# Altium schematic sheet HAL_3.SchDoc
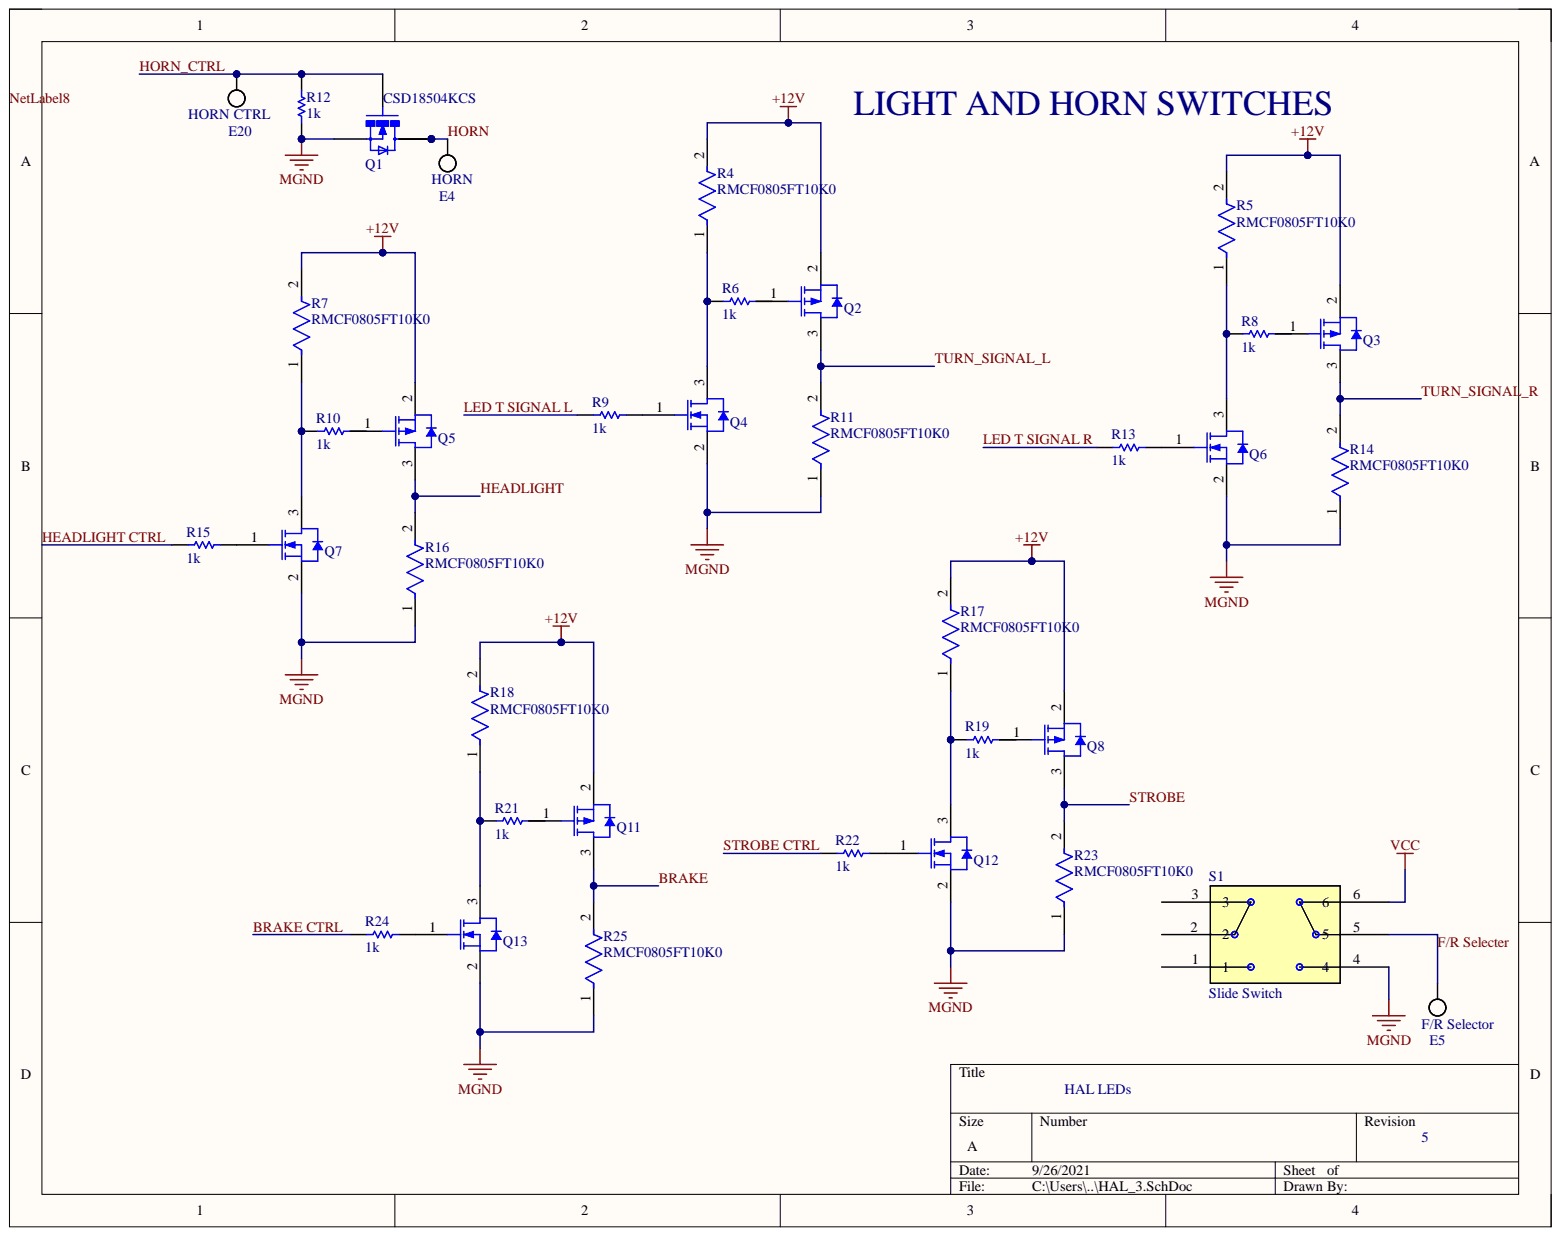
[diagram p0: HAL_3.SchDoc]

## Parts
E4  HORN  [EPAD]  (no BOM)
E5  F/R Selector  [EPAD]  (no BOM)
E20  HORN CTRL  [EPAD]  (no BOM)
Q1  CSD18504KCS  [TO254P1651X1067X470-3]
Q2  IRLML6402TRPBF  [INTR-SOT-23-3_V]  (value not drawn)
Q3  IRLML6402TRPBF  [INTR-SOT-23-3_V]  (value not drawn)
Q4  NTR4501NT1G  [ONSC-SOT-23-3-318-08_V]  (value not drawn)
Q5  IRLML6402TRPBF  [INTR-SOT-23-3_V]  (value not drawn)
Q6  NTR4501NT1G  [ONSC-SOT-23-3-318-08_V]  (value not drawn)
Q7  NTR4501NT1G  [ONSC-SOT-23-3-318-08_V]  (value not drawn)
Q8  IRLML6402TRPBF  [INTR-SOT-23-3_V]  (value not drawn)
Q11  IRLML6402TRPBF  [INTR-SOT-23-3_V]  (value not drawn)
Q12  NTR4501NT1G  [ONSC-SOT-23-3-318-08_V]  (value not drawn)
Q13  NTR4501NT1G  [ONSC-SOT-23-3-318-08_V]  (value not drawn)
R4  RMCF0805FT10K0  [FP-RMCF0805-MFG]
R5  RMCF0805FT10K0  [FP-RMCF0805-MFG]
R6  1k  [RESC3216X70X40LL20T20]
R7  RMCF0805FT10K0  [FP-RMCF0805-MFG]
R8  1k  [RESC3216X70X40LL20T20]
R9  1k  [RESC3216X70X40LL20T20]
R10  1k  [RESC3216X70X40LL20T20]
R11  RMCF0805FT10K0  [FP-RMCF0805-MFG]
R12  1k  [RESC3216X70X40LL20T20]
R13  1k  [RESC3216X70X40LL20T20]
R14  RMCF0805FT10K0  [FP-RMCF0805-MFG]
R15  1k  [RESC3216X70X40LL20T20]
R16  RMCF0805FT10K0  [FP-RMCF0805-MFG]
R17  RMCF0805FT10K0  [FP-RMCF0805-MFG]
R18  RMCF0805FT10K0  [FP-RMCF0805-MFG]
R19  1k  [RESC3216X70X40LL20T20]
R21  1k  [RESC3216X70X40LL20T20]
R22  1k  [RESC3216X70X40LL20T20]
R23  RMCF0805FT10K0  [FP-RMCF0805-MFG]
R24  1k  [RESC3216X70X40LL20T20]
R25  RMCF0805FT10K0  [FP-RMCF0805-MFG]
S1  Slide Switch  [Slide Switch]

## Nets
+12V: Q2.2 Q3.2 Q5.2 Q8.2 Q11.2 R4.2 R5.2 R7.2 R17.2 R18.2
MGND: Q1.3 Q4.2 Q6.2 Q7.2 Q12.2 Q13.2 R11.1 R12.2 R14.1 R16.1 R23.1 R25.1 S1.4
VCC: S1.6
BRAKE: Q11.3 R25.2
BRAKE CTRL: R24.1
F/R Selecter: E5.1 S1.5
HEADLIGHT: Q5.3 R16.2
HEADLIGHT CTRL: R15.1
HORN: E4.1 Q1.2 Q1.4 Q1.4.1
HORN_CTRL: E20.1 Q1.1 R12.1
LED T SIGNAL L: R9.1
LED T SIGNAL R: R13.1
STROBE: Q8.3 R23.2
STROBE CTRL: R22.1
TURN_SIGNAL_L: Q2.3 R11.2
TURN_SIGNAL_R: Q3.3 R14.2
NetQ4_1: Q4.1 R9.2
NetQ4_3: Q4.3 R4.1 R6.1
NetQ6_1: Q6.1 R13.2
NetQ6_3: Q6.3 R5.1 R8.1
NetQ7_1: Q7.1 R15.2
NetQ7_3: Q7.3 R7.1 R10.1
NetQ12_1: Q12.1 R22.2
NetQ12_3: Q12.3 R17.1 R19.1
NetQ13_1: Q13.1 R24.2
NetQ13_3: Q13.3 R18.1 R21.1
NetLabel8:

Not connected: Q2 1; Q3 1; Q5 1; Q8 1; Q11 1; R6 2; R8 2; R10 2; R19 2; R21 2; S1 1 2 3

## Library data of the parts
E4 E5 E20 = EPAD: Pad for probing signals; Library Name: PrISUm-Discrete.SchLib
Q1 = CSD18502KCS: 40V, N ch NexFET MOSFET, single TO-220, 2.9mOhm 3-TO-220 -55 to 175; MF: Texas Instruments; Availability: Good; Check_prices: https://www.snapeda.com/parts/CSD18502KCS/Texas%20Instruments/view-part/277573/?ref=eda; Component Kind: Standard; Library Name: CSD18502KCS.IntLib; MP: CSD18502KCS; Package: TO-220-3 Texas Instruments; Price: None; Purchase-URL: https://pricing.snapeda.com/search/part/CSD18502KCS/?ref=eda; SnapEDA_Link: https://www.snapeda.com/parts/CSD18502KCS/Texas%20Instruments/view-part/277573/?ref=snap
Q2 Q3 Q5 Q8 Q11 = CMP-2000-04987-1: HEXFET(R) Power P-Channel MOSFET, -20 V, -3.7 A, -55 to 150 degC, 3-Pin SOT-23, RoHS, Tape and Reel; Manufacturer: International Rectifier; Drain-Source On Resistance-Max: 0.065O; Drain-to-Source Voltage [Vdss]: 20V; Qg Gate Charge: 8nC; Rated Power Dissipation: 1.3W; Channel Type: P-Channel; Component Kind: Standard; Datasheet URL: http://datasheet.octopart.com/IRLML6402TRPBF-International-Rectifier-datasheet-93882.pdf; Datasheet Version: Feb-05; Library Name: Altium Content Vault; Manufacturer URL: http://internationalrectifier.com/; Package Description: 3-Pin Small Outline Transistor, Body 2.92 x 1.3 mm, Pitch 0.95 mm; Package Reference: SOT-23-3
Q4 Q6 Q7 Q12 Q13 = CMP-1058-00732-1: Power MOSFET, 20 V, 3.2 A, Single N-Channel, 3-Pin SOT-23, Pb-Free, Tape and Reel; Manufacturer: On Semiconductor; Channel Polarity: N Channel; Ciss Typ (pF): 200; Coss Typ (pF): 80; Crss Typ (pF): 50; PD Max (W): 1.25; Qrr Typ (nC): 3; V(BR)DSS Min (V): 20; VGS Max (V): 12; VGS(th) Max (V): 1.2; Component Kind: Standard; ComponentLink1Description: Manufacturer URL; ComponentLink1URL: http://www.onsemi.com/; ComponentLink2Description: Datasheet; ComponentLink2URL: http://www.onsemi.cn/pub_link/Collateral/NTR4501N-D.PDF; ComponentLink3Description: Package Specification; ComponentLink3URL: http://www.onsemi.cn/pub_link/Collateral/318-08.PDF; Configuration: Single; DatasheetVersion: Rev. 13; Library Name: Altium Content Vault; Mounting Technology: Surface Mount; Package Type: SOT-23-3; PackageDescription: 3-Pin Small Outline Transistor, Body 2.92 x 1.3 mm, Pitch 0.95 mm; PackageReference: SOT-23-3-318-08; PackageVersion: Rev. AP, 11/2009; Packing: Tape and Reel; PartNumber: NTR4501NT1G; RoHS: Pb-Free
R4 R5 R7 R11 R14 R16-R18 R23 R25 = CMP-26527-000121-1: RES 10K OHM 1% 1/8W 0805; Max Power Dissipation: 125mW; Resistance: 10kR; Temperature Coefficient: 100ppm/°C; Case Code (Imperial): 0805; Case Code (Metric): 2012; Case/Package: 0805; Component Kind: Standard; Construction: Rectangular; Contact Plating: Tin; Depth: 1.25mm; Features: Automotive AEC-Q200; Height: 0.026inch; Lead Free: Lead Free; Length: 2mm; Library Name: Altium Content Vault; Max Operating Temperature: 155°C; Military Standard: Not; Min Operating Temperature: -55°C; Mount: Surface Mount; Number of Terminations: 2; Packaging: Tape and Reel; Power Rating: 125mW; Radiation Hardening: No; Resistor Type: Thick Film; RoHS Compliant: Yes; Series: RMCF; Size Code: 0805; Tolerance: 1%; Width: 0.049inch
R6 R8-R10 R12 R13 R15 R19 R21 R22 R24 = CMP-2003-04517-1: RK73B2BTTD102J; Manufacturer: KOA Speer; Component Kind: Standard; Library Name: Altium Content Vault; Part Number: RK73B2BTTD102J
S1 = Slide Switch: Component Kind: Standard; Library Name: PrISUm-Discrete.SchLib
